# Altium schematic sheet FMRadio.SchDoc(FMRadio)
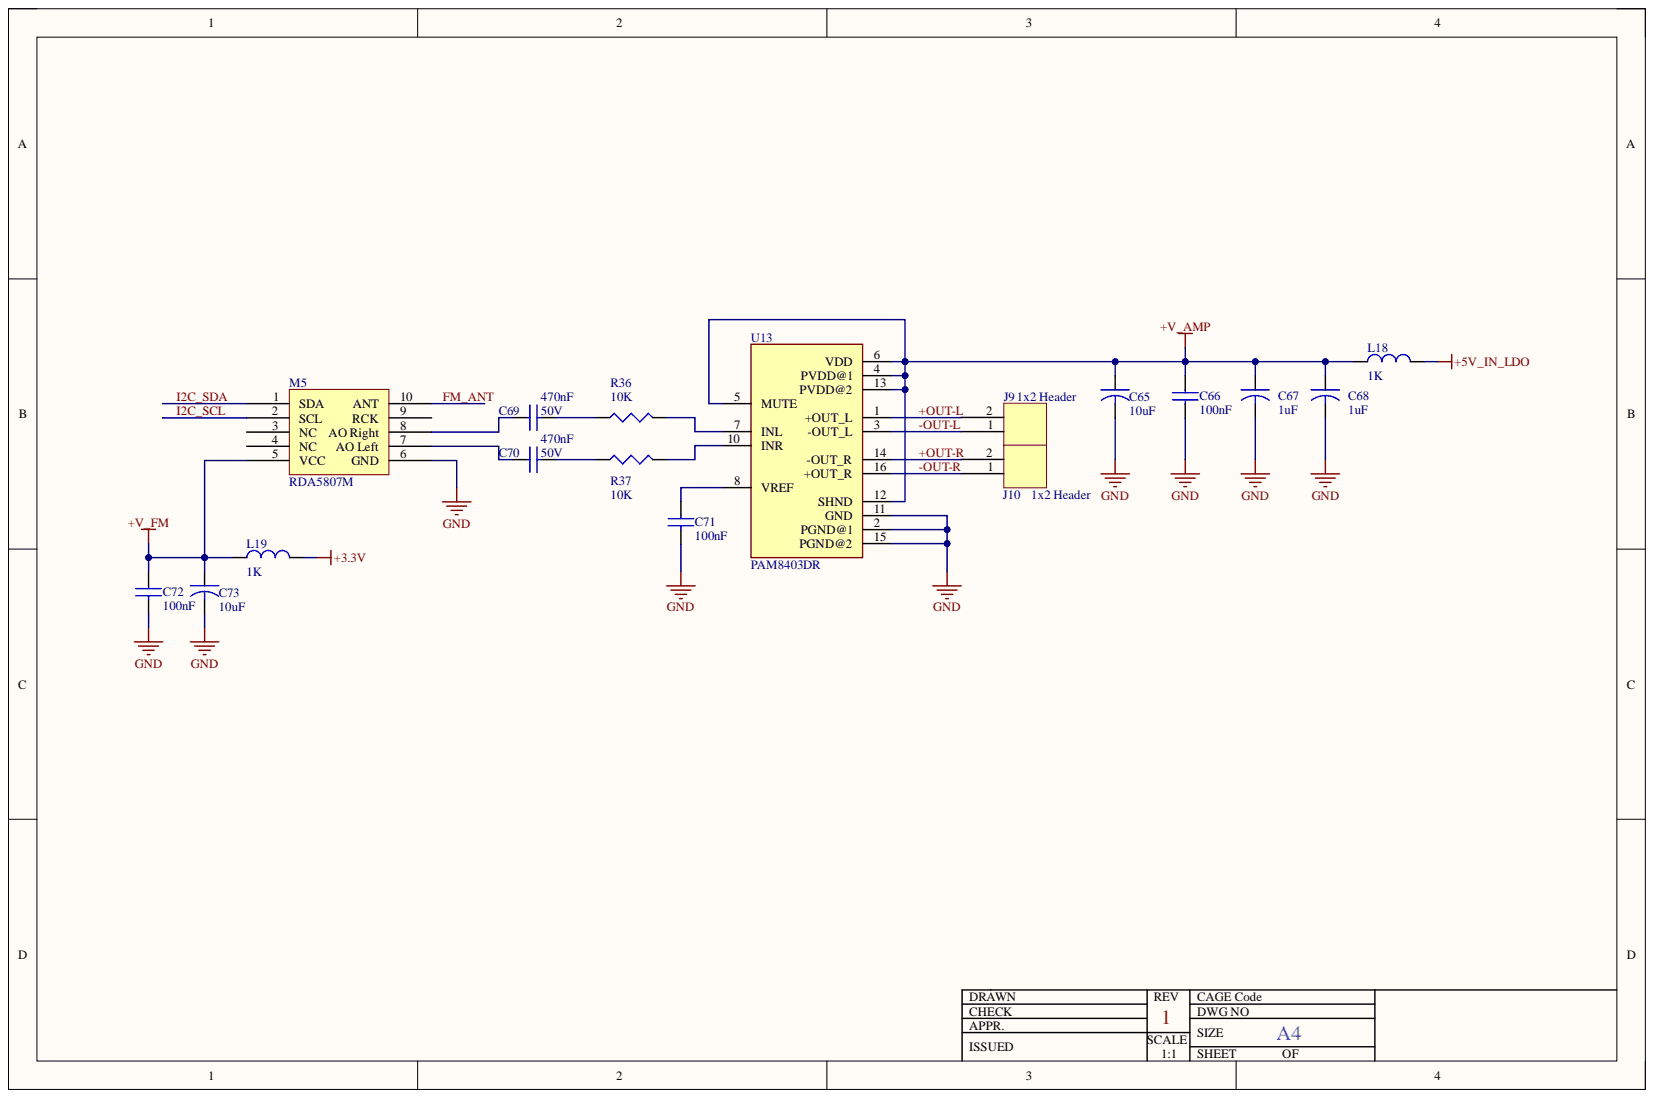
[diagram p0: FMRadio.SchDoc]

## Parts
C65  value 10uF  [A Case]  (hidden comment: T491A106)
C66  value 100nF  [0805 SMD Ceramic Capacitor]  (hidden comment: C0805C104)
C67  value 1uF  [A Case]  (hidden comment: T491A105)
C68  value 1uF  [A Case]  (hidden comment: T491A105)
C69  value 470nF  Voltage: 50V  [0805 SMD Ceramic Capacitor]  (hidden comment: C0805C474)
C70  value 470nF  Voltage: 50V  [0805 SMD Ceramic Capacitor]  (hidden comment: C0805C474)
C71  value 100nF  [0805 SMD Ceramic Capacitor]  (hidden comment: C0805C104)
C72  value 100nF  [0805 SMD Ceramic Capacitor]  (hidden comment: C0805C104)
C73  value 10uF  [A Case]  (hidden comment: T491A106)
J9  value 1x2 Header  [1x2 2 Pin Header]  (hidden comment: 1x2 2 Pin Header)
J10  value 1x2 Header  [1x2 2 Pin Header]  (hidden comment: 1x2 2 Pin Header)
L18  value 1K  [0805 Inductor]  (hidden comment: 0805Ferrite)
L19  value 1K  [0805 Inductor]  (hidden comment: 0805Ferrite)
M5  RDA5807M  [RDA5807M]
R36  value 10K  [0805 Resistor]  (hidden comment: 0805_10K)
R37  value 10K  [0805 Resistor]  (hidden comment: 0805_10K)
U13  PAM8403DR  [SO16]

## Nets
+3.3V: L19.2
+5V_IN_LDO: L18.2
+V_AMP: C65.1 C66.1 C67.1 C68.1 L18.1 U13.4(PVDD@1) U13.5(MUTE) U13.6(VDD) U13.12(SHND) U13.13(PVDD@2)
+V_FM: C72.1 C73.1 L19.1 M5.5(VCC)
GND: C65.2 C66.2 C67.2 C68.2 C71.2 C72.2 C73.2 M5.6(GND) U13.2(PGND@1) U13.11(GND) U13.15(PGND@2)
+OUT-L: J9.2 U13.1(+OUT_L)
+OUT-R: J10.2 U13.14(-OUT_R)
-OUT-L: J9.1 U13.3(-OUT_L)
-OUT-R: J10.1 U13.16(+OUT_R)
FM_ANT: M5.10(ANT)
I2C_SCL: M5.2(SCL)
I2C_SDA: M5.1(SDA)
NetC69_1: C69.1 M5.8(AO_Right)
NetC69_2: C69.2 R36.1
NetC70_1: C70.1 M5.7(AO_Left)
NetC70_2: C70.2 R37.1
NetC71_1: C71.1 U13.8(VREF)
NetR36_2: R36.2 U13.7(INL)
NetR37_2: R37.2 U13.10(INR)

Not connected: M5 3(NC) 4(NC) 9(RCK)

## Library data of the parts
C65 C73 = T491A106: Manufacturer: KEMET; Manufacturer Code: T491A106K006AT; Voltage: 6V3; Component Kind: Standard; Farnell Code: 1457412; Library Name: Capacitors.SchLib; Unit Price: 0,143 €
C66 C71 C72 = C0805C104: Manufacturer: KEMET; Manufacturer Code: C0805C104J5RACTU; Voltage: 50V; Component Kind: Standard; Farnell Code: 1414663; Library Name: Capacitors.SchLib; Package: 0805; Unit Price: 0,06 €
C67 C68 = T491A105: Manufacturer: KEMET; Manufacturer Code: T491A105M016AT; Capacitance Tolerance: ±20%; Voltage: 16V; Component Kind: Standard; Farnell Code: 1163340; Library Name: Capacitors.SchLib; MOQ: 1; Unit Price: 0,143 €
C69 C70 = C0805C474: Manufacturer: AVX; Manufacturer Code: 08055C474K4T2A; Component Kind: Standard; Farnell Code: 1833885; Library Name: Capacitors.SchLib; Package: 0805; Unit Price: 0,20 €
L18 L19 = 0805Ferrite: Manufacturer: TDK; Manufacturer Code: MMZ2012R102A; Current: 500mA; Component Kind: Standard; Farnell Code: 1669719; Library Name: FerriteBead.SchLib; Unit Price: 0,059 €
M5 = RDA5807M: FM Radio Module; Manufacturer: RDA Microelectronics; Component Kind: Standard; Library Name: RDA5807M.IntLib; Manufacurer Code: RDA5807M; Price Unit: $; Unit Price: 0,50; Value: RDA5807M
R36 R37 = 0805_10K: Manufacturer: MULTICOMP; Manufacturer Code: MC 0.1W 0805 1% 10K; Tolerance: ±1%; Component Kind: Standard; Farnell Code: 9332391; Library Name: Resistors.SchLib; Unit Price: 0,03 €
U13 = PAM8403DR: Amplifier IC 2-Channel (Stereo) Class D 16-SOP; Manufacurer Code: Diodes Incorporated; Component Kind: Standard; Library Name: PAM8403DR.SchLib; Manufacurer: PAM8403DR; Package: SO-16; Price Unit: $; Unit Price: 1,39; Value: PAM8403DR
J9 J10 = 1x2 2 Pin Header: Component Kind: Standard; Library Name: Header.SchLib
